# Altium schematic sheet Sheet1.SchDoc(Sheet1)
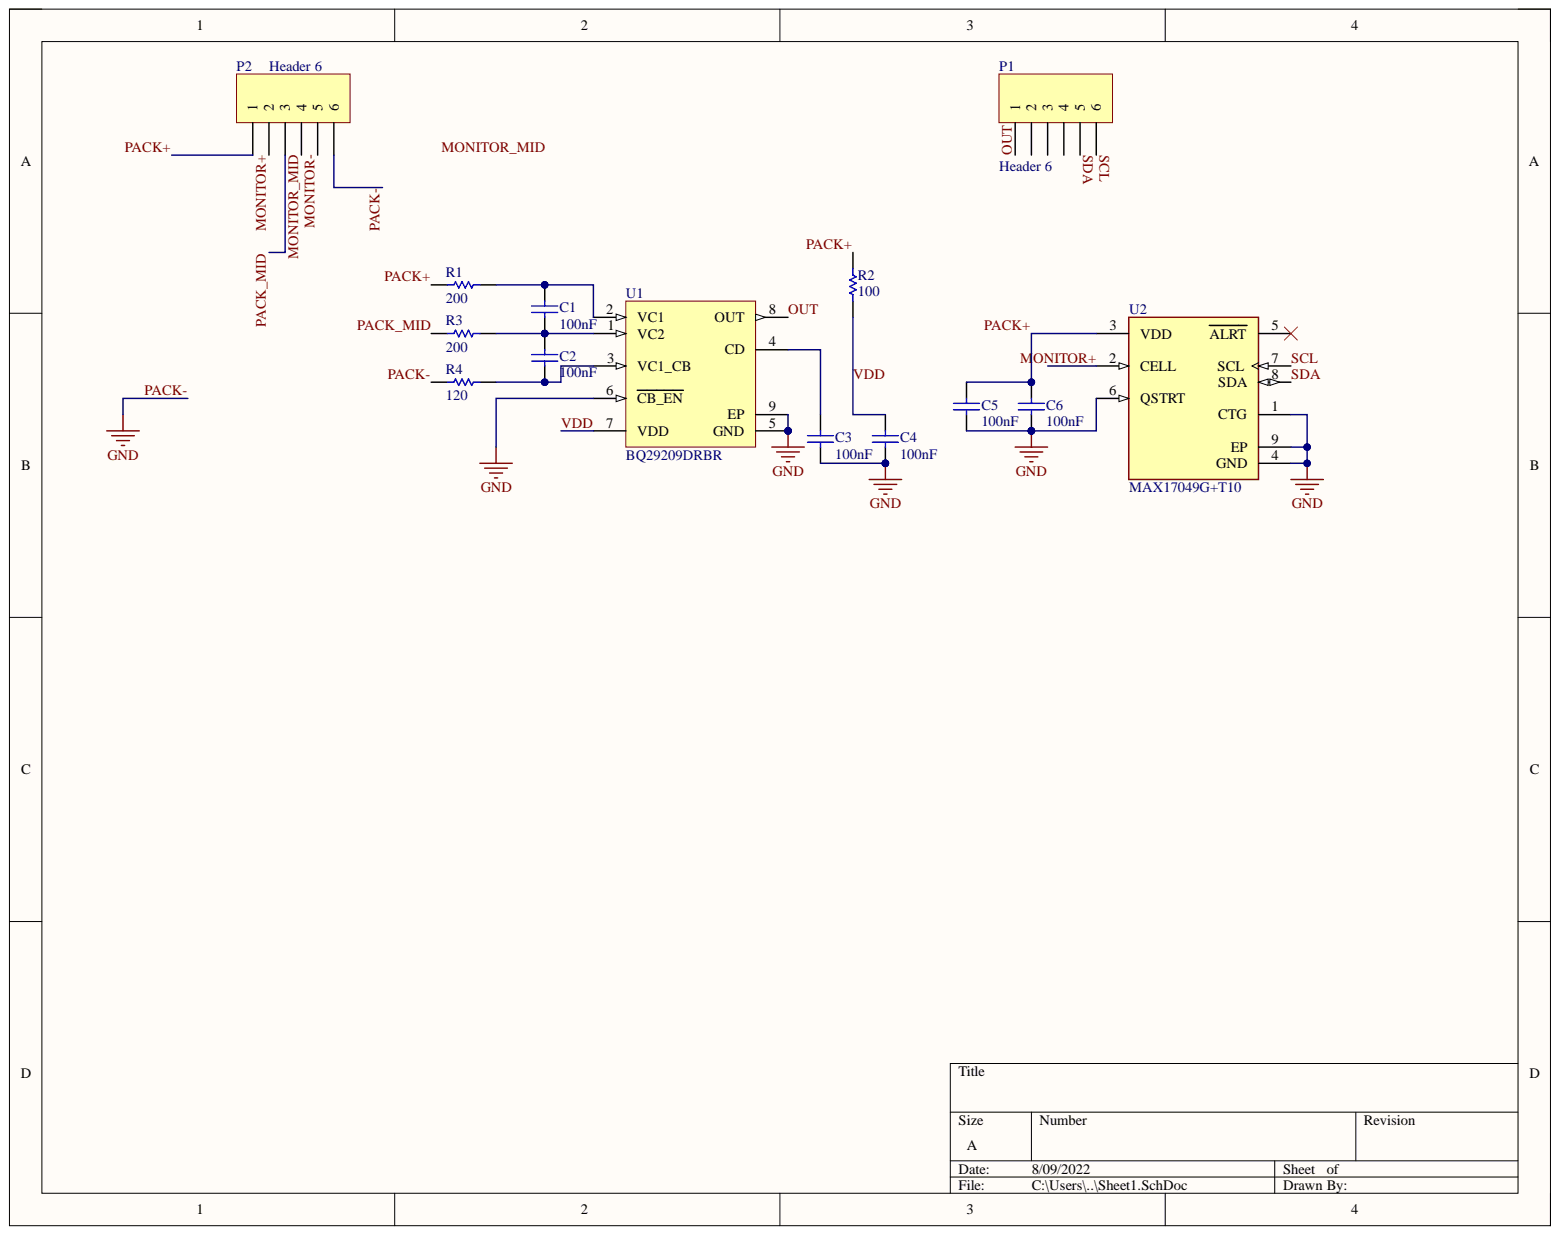
[diagram p0: Sheet1.SchDoc]

## Parts
C1  Capacitance: 100nF  [CAPC2013X135X45ML10T25]  (hidden comment: GRM21BR71H104KA01L)
C2  Capacitance: 100nF  [CAPC2013X135X45ML10T25]  (hidden comment: GRM21BR71H104KA01L)
C3  Capacitance: 100nF  [CAPC2013X135X45ML10T25]  (hidden comment: GRM21BR71H104KA01L)
C4  Capacitance: 100nF  [CAPC2013X135X45ML10T25]  (hidden comment: GRM21BR71H104KA01L)
C5  Capacitance: 100nF  [CAPC2013X135X45ML10T25]  (hidden comment: GRM21BR71H104KA01L)
C6  Capacitance: 100nF  [CAPC2013X135X45ML10T25]  (hidden comment: GRM21BR71H104KA01L)
P1  Header 6  [HDR1X6]
P2  Header 6  [HDR1X6]
R1  CRCW0805200RJNEA  [RESC2013X50X30ML20T20]  (value not drawn)
R2  CRCW0805100RFKEA  [RESC2013X50X30NL20T20]  (value not drawn)
R3  CRCW0805200RJNEA  [RESC2013X50X30ML20T20]  (value not drawn)
R4  ERJ-6ENF1200V  [RESC2013X70X40NL20T20]  (value not drawn)
U1  BQ29209DRBR  [DRB0008B_V]
U2  MAX17049G+T10  [FP-T822-3-MFG]

## Nets
MONITOR+: P2.2 U2.2(CELL)
MONITOR-: P2.5
MONITOR_MID: P2.4
OUT: P1.1 U1.8(OUT)
PACK+: C5.1 C6.2 P2.1 R1.1 R2.2 U2.3(VDD)
PACK-: C3.1 C4.1 C5.2 C6.1 P2.6 R4.1 U1.5(GND) U1.6(CB_EN) U1.9(EP) U2.1(CTG) U2.4(GND) U2.6(QSTRT) U2.9(EP)
PACK_MID: P2.3 R3.1
SCL: P1.6 U2.7(SCL)
SDA: P1.5 U2.8(SDA)
VDD: C4.2 R2.1 U1.7(VDD)
NetC1_1: C1.1 C2.2 R3.2 U1.1(VC2)
NetC1_2: C1.2 R1.2 U1.2(VC1)
NetC2_1: C2.1 R4.2 U1.3(VC1_CB)
NetC3_2: C3.2 U1.4(CD)

Not connected: P1 2 3 4; U2 5(ALRT)

## Library data of the parts
C1-C6 = CMP-2100-03644-1: Chip Capacitor, 0.1 uF, +/- 10%, 50 V, -55 to 125 degC, 0805 (2012 Metric), RoHS, Tape and Reel; Manufacturer: Murata; Dielectric: X7R; Dielectric Material: Ceramic; Insulation Resistance: 10GR; Voltage: 50V; Case Code (Imperial): 0805; Case Code (Metric): 2012; Case/Package: 0805; Component Kind: Standard; Datasheet URL: http://psearch.en.murata.com/capacitor/product/GRM21BR71H104KA01%23.pdf; Datasheet Version: 42675.0; Depth: 1.25mm; Height: 1.25mm; Lead Free: LeadFree; Length: 2mm; Library Name: Altium Content Vault; Manufacturer URL: http://www.murata.com/; Material: Ceramic; Max Operating Temperature: 125degC; Min Operating Temperature: -55degC; Mount: SurfaceMount; Number of Pins: 2; Package Description: 2-Pin Surface Mount Device, Body 2 x 1.25 mm; Package Quantity: 1; Package Reference: 0805; Packaging: TapeandReel; Radiation Hardening: No; REACH SVHC: Unknown; RoHSCompliant: true; Termination: SMD/SMT; Thickness: 0.053inch; Tolerance: 10%; Voltage Rating: 50V; Voltage Rating (DC): 50V; Width: 0.049inch
P1 P2 = Header 6: Header, 6-Pin; Component Kind: Standard; LatestRevisionDate: 17-Jul-2002; LatestRevisionNote: Re-released for DXP Platform.; Library Name: Miscellaneous Connectors.IntLib; Signal Integrity: Connector; Publisher: Altium Limited
R1 R3 = CMP-2001-03664-1: Manufacturer: Vishay Dale; R: 200; Component Kind: Standard; Library Name: Altium Content Vault; Part Number: CRCW0805200RJNEA
R2 = CMP-2001-04901-1: Manufacturer: Vishay Dale; r: 100; Component Kind: Standard; Library Name: Altium Content Vault; Part Number: CRCW0805100RFKEA
R4 = CMP-2001-00511-5: Chip Resistor, 120 Ohm, +/- 1%, 0.125 W, -55 to 155 degC, 0805 (2012 Metric), RoHS, Tape and Reel; Manufacturer: Panasonic; R: 120; Voltage Rating: 150 V; Component Kind: Standard; ComponentLink1Description: Manufacturer URL; ComponentLink1URL: http://www.panasonic.com/; ComponentLink2Description: Datasheet; ComponentLink2URL: http://industrial.panasonic.com/cdbs/www-data/pdf/RDA0000/AOA0000C86.pdf; ComponentLink3Description: New link; DatasheetVersion: 04/2015; Height: 0.6 mm; Length: 2 mm; Library Name: Altium Content Vault; Max Operating Temperature: 155 degC; Min Operating Temperature: -55 degC; Mount: Surface Mount; Mounting Technology: Surface Mount; Package Quantity: 5000; PackageDescription: 2-Pin Surface Mount Device, Body 2 x 1.25 mm; PackageReference: 0805; Packaging: Tape and Reel; Pins: 2; REACH SVHC: Yes; RoHSCompliant: true
U1 = CMP-0321-00626-2: Voltage Protection with Automatic Cell Balance for 2-Cell Li-Ion Batteries, OVP=4.3 V, -40 to 85 degC, 8-pin SON (DRB), Green (RoHS & no Sb/Br); Manufacturer: Texas Instruments; Lead Acid: N; SubFamily: Lithium Ion Protection; Component Kind: Standard; ComponentLink1Description: Datasheet; ComponentLink1URL: http://www.ti.com/general/docs/lit/getliterature.tsp?genericPartNumber=BQ29209&fileType=pdf; DatasheetVersion: SLUSA52A; Eco-Plan: Green (RoHS & no Sb/Br); Li-Ion: N; Li-Polymer: N; Library Name: Altium Content Vault; Mounting Technology: Surface Mount; NiCd: N; NiMH: N; Over Voltage Protection: N; PackageDescription: 8-Pin Plastic Small Outline No-Lead, 3 x 3 x 1 mm Body, 0.65 mm Pitch, Exposed Pad; PackageReference: DRB0008B; PackageVersion: revK, Jun-2012; PartNumber: BQ29209DRBR
U2 = CMP-05715-000114-1: IC FUEL GAUGE LI-ION 2CELL 8TDFN; Manufacturer: Maxim Integrated; Max Supply Voltage: 4.5V; Min Supply Voltage: 2.5V; Nominal Supply Current: 23uA; Number Of Cells: 2; Standoff Height: 0mm; Automotive: No; Category: IC; Component Kind: Standard; ComponentLink2Description: Datasheet; ComponentLink2URL: https://datasheets.maximintegrated.com/en/ds/MAX17048-MAX17049.pdf; Device Class L1: Integrated Circuits (ICs); Device Class L2: Power Management ICs; Device Class L3: Battery Management; Digikey Description: IC FUEL GAUGE LI-ION 2CELL 8TDFN; Footprint Url: https://pdfserv.maximintegrated.com/land_patterns/90-0065.PDF; Height: 0.8mm; Lead Free: Yes; Library Name: Altium Content Vault; Manufacturer Part Number: MAX17049G+T10; Mouser Description: Fuel Gauge Li-Ion 8-Pin TDFN EP T/R; Octopart: https://octopart.com/max17049g%2Bt10-maxim+integrated-20327626; Package: T822-3; RoHS: TRUE; Temperature: -40°C to +85°C; Temperature Range High: +85°C; Temperature Range Low: -40°C
